# Altium schematic sheet MM_Radar.SchDoc(MM_Radar)
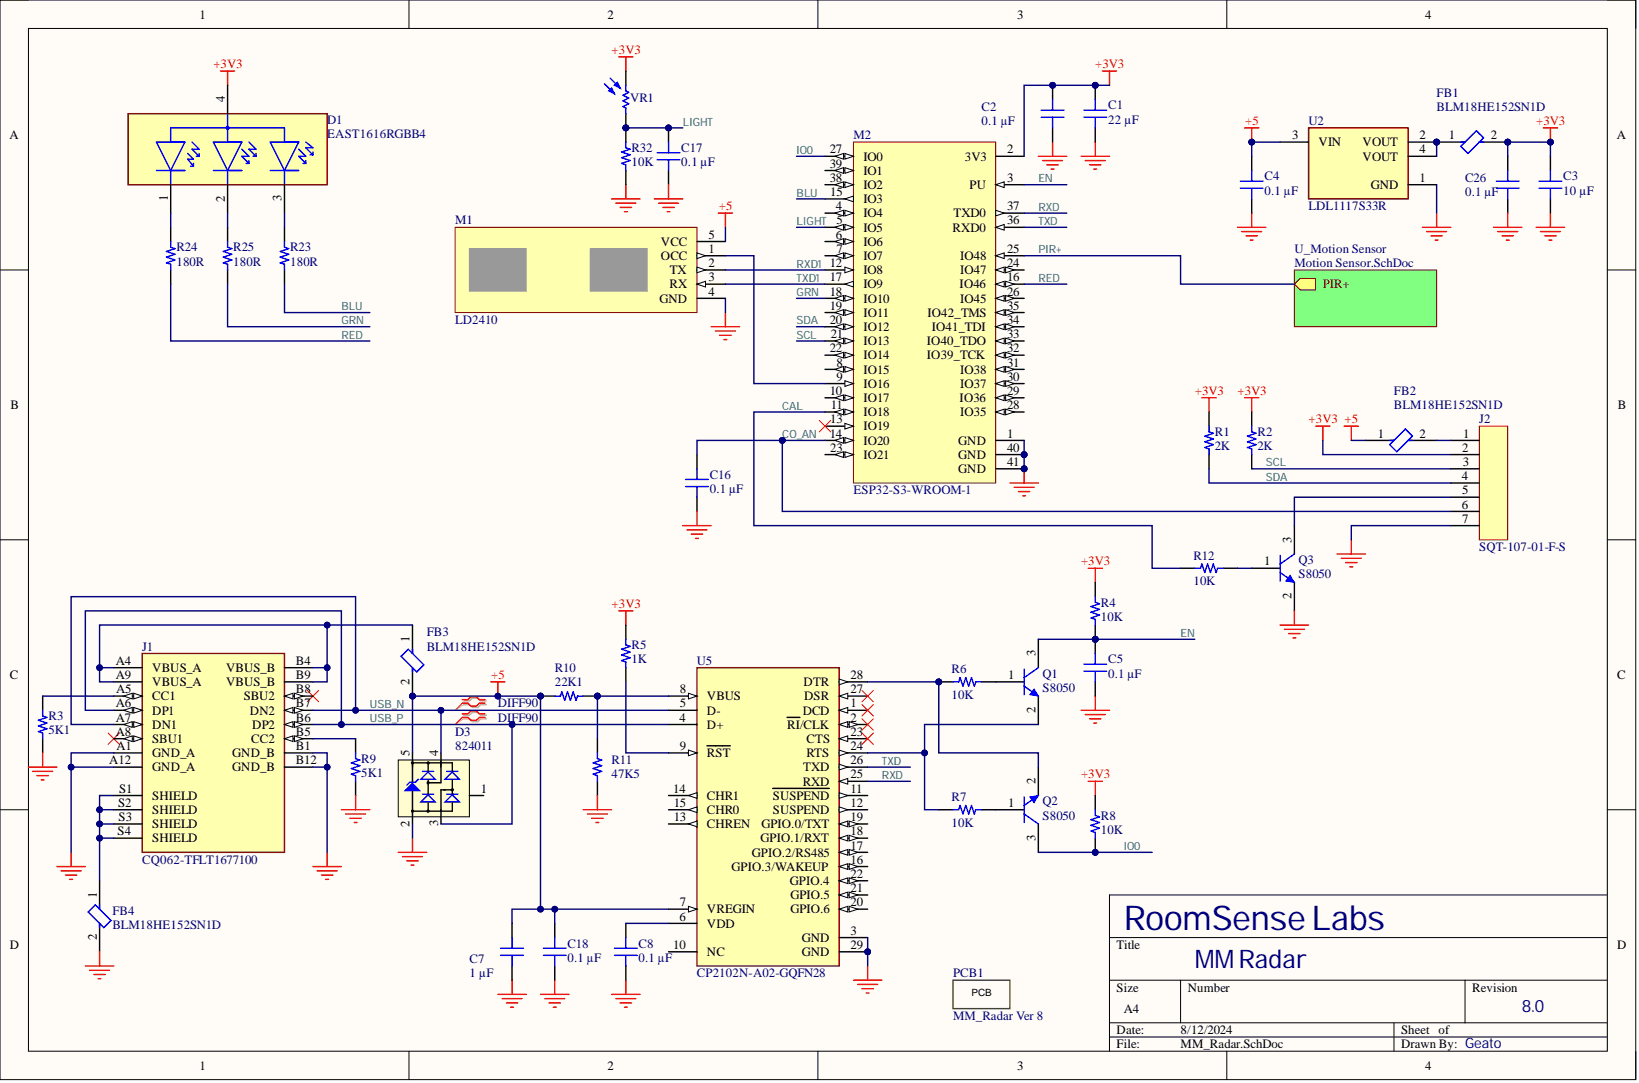
[diagram p0: MM_Radar.SchDoc]

## Parts
C1  22 µF
C2  0.1 µF
C3  10 µF
C4  0.1 µF
C5  0.1 µF
C7  1 µF
C8  0.1 µF
C16  0.1 µF
C17  0.1 µF
C18  0.1 µF
C26  0.1 µF
D1  EAST1616RGBB4
D3  824011
FB1  BLM18HE152SN1D
FB2  BLM18HE152SN1D
FB3  BLM18HE152SN1D
FB4  BLM18HE152SN1D
J1  CQ062-TFLT1677100
J2  SQT-107-01-F-S
M1  LD2410
M2  ESP32-S3-WROOM-1
PCB1  MM_Radar Ver 8  (mechanical)
Q1  S8050
Q2  S8050
Q3  S8050
R1  2K
R2  2K
R3  5K1
R4  10K
R5  1K
R6  10K
R7  10K
R8  10K
R9  5K1
R10  22K1
R11  47K5
R12  10K
R23  180R
R24  180R
R25  180R
R32  10K
U2  LDL1117S33R
U5  CP2102N-A02-GQFN28
VR1  AF161  (value not drawn)

## Nets
+3V3: C1.2 C2.2 C3.2 C26.2 D1.4 FB1.2 J2.2 M2.2(3V3) R1.2 R2.2 R4.2 R5.2 R8.1 VR1.2
+5: C4.2 C7.2 C18.2 D3.5 FB2.1 FB3.2 M1.5(VCC) R10.1 U2.3(VIN) U5.7(VREGIN)
GND: C1.1 C2.1 C3.1 C4.1 C5.1 C7.1 C8.1 C16.1 C17.1 C18.1 C26.1 D3.2 FB4.2 J1.A1(GND_A) J1.A12(GND_A) J1.B1(GND_B) J1.B12(GND_B) J2.7 M1.4(GND) M2.1(GND) M2.40(GND) M2.41(GND) Q3.2 R3.1 R9.1 R11.1 R32.1 U2.1(GND) U5.3(GND) U5.29(GND)
BLU: M2.15(IO3) R23.1
CAL: M2.11(IO18) R12.1
CO_AN: C16.2 J2.6 M2.14(IO20)
EN: C5.2 M2.3(PU) Q1.3 R4.1
GRN: M2.18(IO10) R25.1
IO0: M2.27(IO0) Q2.3 R8.2
LIGHT: C17.2 M2.5(IO5) R32.2 VR1.1
PIR+: M2.25(IO48)
RED: M2.16(IO46) R24.1
RXD: M2.37(TXD0) U5.25(RXD)
RXD1: M1.2(TX) M2.12(IO8)
SCL: J2.3 M2.21(IO13) R2.1
SDA: J2.4 M2.20(IO12) R1.1
TXD: M2.36(RXD0) U5.26(TXD)
TXD1: M1.3(RX) M2.17(IO9)
USB_N: D3.4 J1.A7(DN1) J1.B7(DN2) U5.5(D-)
USB_P: D3.3 J1.A6(DP1) J1.B6(DP2) U5.4(D+)
NetC8_2: C8.2 U5.6(VDD)
NetD1_1: D1.1 R24.2
NetD1_2: D1.2 R25.2
NetD1_3: D1.3 R23.2
NetD3_1: D3.1
NetFB1_1: FB1.1 U2.2(VOUT) U2.4(VOUT)
NetFB2_2: FB2.2 J2.1
NetFB3_1: FB3.1 J1.A4(VBUS_A) J1.A9(VBUS_A) J1.B4(VBUS_B) J1.B9(VBUS_B)
NetFB4_1: FB4.1 J1.S1(SHIELD) J1.S2(SHIELD) J1.S3(SHIELD) J1.S4(SHIELD)
NetJ1_A5: J1.A5(CC1) R3.2
NetJ1_A8: J1.A8(SBU1)
NetJ1_B5: J1.B5(CC2) R9.2
NetJ1_B8: J1.B8(SBU2)
NetJ2_5: J2.5 Q3.3
NetM1_1: M1.1(OCC) M2.9(IO16)
NetM2_4: M2.4(IO4)
NetM2_6: M2.6(IO6)
NetM2_7: M2.7(IO7)
NetM2_8: M2.8(IO15)
NetM2_10: M2.10(IO17)
NetM2_13: M2.13(IO19)
NetM2_19: M2.19(IO11)
NetM2_22: M2.22(IO14)
NetM2_23: M2.23(IO21)
NetM2_24: M2.24(IO47)
NetM2_26: M2.26(IO45)
NetM2_28: M2.28(IO35)
NetM2_29: M2.29(IO36)
NetM2_30: M2.30(IO37)
NetM2_31: M2.31(IO38)
NetM2_32: M2.32(IO39_TCK)
NetM2_33: M2.33(IO40_TDO)
NetM2_34: M2.34(IO41_TDI)
NetM2_35: M2.35(IO42_TMS)
NetM2_38: M2.38(IO2)
NetM2_39: M2.39(IO1)
NetQ1_1: Q1.1 R6.2
NetQ1_2: Q1.2 R7.1 U5.24(RTS)
NetQ2_1: Q2.1 R7.2
NetQ2_2: Q2.2 R6.1 U5.28(DTR)
NetQ3_1: Q3.1 R12.2
NetR5_1: R5.1 U5.9(RST)
NetR10_2: R10.2 R11.2 U5.8(VBUS)
NetU5_1: U5.1(DCD)
NetU5_2: U5.2(RI/CLK)
NetU5_10: U5.10(NC)
NetU5_11: U5.11(SUSPEND)
NetU5_12: U5.12(SUSPEND)
NetU5_13: U5.13(CHREN)
NetU5_14: U5.14(CHR1)
NetU5_15: U5.15(CHR0)
NetU5_16: U5.16(GPIO.3/WAKEUP)
NetU5_17: U5.17(GPIO.2/RS485)
NetU5_18: U5.18(GPIO.1/RXT)
NetU5_19: U5.19(GPIO.0/TXT)
NetU5_20: U5.20(GPIO.6)
NetU5_21: U5.21(GPIO.5)
NetU5_22: U5.22(GPIO.4)
NetU5_23: U5.23(CTS)
NetU5_27: U5.27(DSR)

## Library data of the parts
C1 = CC0805MKX5R6BB226: CAP CER 22UF 10V X5R 0805; manufacturer: Yageo; Database Table Name: Capacitors - Ceramic - 0805; Tolerance: ±20%; Applications: General Purpose; Capacitance: 22 µF; Component Kind: Standard; componentlink1description: Datasheet; componentlink1url: https://www.yageo.com/upload/media/product/productsearch/datasheet/mlcc/UPY-GPHC_X5R_4V-to-50V_25.pdf; componentlink2description: DigiKey Link; componentlink2url: https://www.digikey.com/en/products/detail/yageo/CC0805MKX5R6BB226/5195306; Device Package: 0805 (2012 Metric); lastupdated: 10/07/2023 7:11:49 PM; Library Name: Celestial Altium Library - altium_library.DbLib; Lifecycle Status: Active; Minimum Order: 1; Mounting Type: Surface Mount, MLCC; Operating Temperature: -55°C ~ 85°C; Package / Case: 0805 (2012 Metric); packaging: TapeAndReel; Part Number: CC0805MKX5R6BB226; Part Status: Active; partid: 8890; price: 0.07; series: CC; Size / Dimension: 0.079" L x 0.049" W (2.00mm x 1.25mm); Supplier 1: DigiKey; Supplier Part Number 1: 311-1900-1-ND; Temperature Coefficient: X5R; Thickness (Max): 0.057" (1.45mm); Value: 22 µF; Voltage - Rated: 10V
C2 C4 C5 C8 C16-C18 C26 = CL10B104KB8NNNL: CAP CER 0.1UF 50V X7R 0603; manufacturer: Samsung Electro-Mechanics; Database Table Name: Capacitors - Ceramic - 0603; Tolerance: ±10%; Applications: General Purpose; Capacitance: 0.1 µF; Component Kind: Standard; componentlink1description: Datasheet; componentlink1url: http://www.samsungsem.com/kr/support/product-search/mlcc/CL10B104KB8NNNL.jsp; componentlink2description: DigiKey Link; componentlink2url: https://www.digikey.com/en/products/detail/samsung-electro-mechanics/CL10B104KB8NNNL/3894274; Device Package: 0603 (1608 Metric); lastupdated: 10/07/2023 7:07:55 PM; Library Name: Celestial Altium Library - altium_library.DbLib; Lifecycle Status: Active; Minimum Order: 1; Mounting Type: Surface Mount, MLCC; Operating Temperature: -55°C ~ 125°C; Package / Case: 0603 (1608 Metric); packaging: CutTape; Part Number: CL10B104KB8NNNL; Part Status: Active; partid: 3517; price: 0.01; series: CL; Size / Dimension: 0.063" L x 0.031" W (1.60mm x 0.80mm); Supplier 1: DigiKey; Supplier Part Number 1: 1276-CL10B104KB8NNNLCT-ND; Temperature Coefficient: X7R; Thickness (Max): 0.035" (0.90mm); Value: 0.1 µF; Voltage - Rated: 50V
C3 = CC0805KKX5R6BB106: CAP CER 10UF 10V X5R 0805; manufacturer: Yageo; Database Table Name: Capacitors - Ceramic - 0805; Tolerance: ±10%; Applications: General Purpose; Capacitance: 10 µF; Component Kind: Standard; componentlink1description: Datasheet; componentlink1url: https://www.yageo.com/upload/media/product/productsearch/datasheet/mlcc/UPY-GPHC_X5R_4V-to-50V_25.pdf; componentlink2description: DigiKey Link; componentlink2url: https://www.digikey.com/en/products/detail/yageo/CC0805KKX5R6BB106/2833625; Device Package: 0805 (2012 Metric); lastupdated: 10/07/2023 7:10:42 PM; Library Name: Celestial Altium Library - altium_library.DbLib; Lifecycle Status: Active; Minimum Order: 1; Mounting Type: Surface Mount, MLCC; Operating Temperature: -55°C ~ 85°C; Package / Case: 0805 (2012 Metric); packaging: TapeAndReel; Part Number: CC0805KKX5R6BB106; Part Status: Active; partid: 44305; price: 0.08; series: CC; Size / Dimension: 0.079" L x 0.049" W (2.00mm x 1.25mm); Supplier 1: DigiKey; Supplier Part Number 1: 311-1460-1-ND; Temperature Coefficient: X5R; Value: 10 µF; Voltage - Rated: 10V
C7 = CC0603KRX7R9BB105: CAP CER 1UF 50V X7R 0603; manufacturer: Yageo; Database Table Name: Capacitors - Ceramic - 0603; Tolerance: ±10%; Applications: General Purpose; Capacitance: 1 µF; Component Kind: Standard; componentlink1description: Datasheet; componentlink1url: https://www.yageo.com/upload/media/product/productsearch/datasheet/mlcc/UPY-GPHC_X7R_6.3V-to-50V_20.pdf; componentlink2description: DigiKey Link; componentlink2url: https://www.digikey.com/en/products/detail/yageo/CC0603KRX7R9BB105/5883796; Device Package: 0603 (1608 Metric); lastupdated: 06/07/2023 7:07:23 PM; Library Name: Celestial Altium Library - altium_library.DbLib; Lifecycle Status: Active; Minimum Order: 1; Mounting Type: Surface Mount, MLCC; Operating Temperature: -55°C ~ 125°C; Package / Case: 0603 (1608 Metric); packaging: TapeAndReel; Part Number: CC0603KRX7R9BB105; Part Status: Active; partid: 45844; price: 0.09; series: CC; Size / Dimension: 0.063" L x 0.031" W (1.60mm x 0.80mm); Supplier 1: DigiKey; Supplier Part Number 1: 311-2082-1-ND; Temperature Coefficient: X7R; Thickness (Max): 0.035" (0.90mm); Value: 1 µF; Voltage - Rated: 50V
D1 = CMP-51564-000009-1: LED RGB CLEAR 4SMD; Color: Blue; Element Configuration: Common Anode; Forward Voltage: 2.8V; Lens Color: Colorless; Lens Size: 1.1mm; Lens Style: Flat; Test Current: 5mA; Viewing Angle: 120°; Case Code (Imperial): 0606; Case Code (Metric): 1616; Case/Package: 0606; Component Kind: Standard; Height: 0.45mm; Length: 1.6mm; Lens Transparency: Clear; Library Name: Altium Content Vault; Mount: Surface Mount; Packaging: Tape and Reel; Width: 1.6mm
D3 = CMP-1474-00002-1: TVS Diode WE-TVS-HS, VRWM=5V; Manufacturer: Wuerth Elektronik; Reverse Stand Off Voltage: 5V; Component Kind: Standard; ComponentLink1Description: Manufacturer URL; ComponentLink1URL: http://www.we-online.com; ComponentLink2Description: Datasheet; ComponentLink2URL: http://katalog.we-online.de/kataloge/eisos/media/pdf/824011.pdf; Library Name: Altium Content Vault; PackageReference: SOT23_5L; PartNumber: 824011
FB1-FB4 = CMP-0686-00368-3: Chip Ferrite Bead, 0603, 1500Ω @ 100MHz, 0.5Ω, 25%, 500mA; DC Resistance (DCR): 500mR; Impedance: 1.5kR; Number of Circuits: 1; Case Code (Imperial): 0603; Case Code (Metric): 1608; Case/Package: 0603; Component Kind: Standard; Core Material: Ferrite; Current Rating: 500mA; DC Current: 500mA; Depth: 0.8mm; Height: 0.8mm; Lead Free: Lead Free; Length: 1.6mm; Library Name: Altium Content Vault; Material: Ferrite; Max Current Rating: 500mA; Max DC Current: 500mA; Max Operating Temperature: 125°C; Military Standard: Not; Min Operating Temperature: -55°C; Number of Lines: 1; Number of Pins: 2; Package Quantity: 1; Packaging: Tape and Reel; Radiation Hardening: No; REACH SVHC: No SVHC; Termination: SMD/SMT; Test Frequency: 100MHz; Tolerance: 25%; Width: 0.8mm
J1 = CMP-00038-1: USC C Connector PCB mount Verticle; Component Kind: Standard; Library Name: Greg Eaton
J2 = CMP-002-00096-2: Headers & Wire Housings Cost Effective Rugged PCB Sockets, 2.00mm pitch; Connector Type: Receptacle; Gender: Female; Number of Contacts: 7; Pitch: 2mm; Voltage Rating (AC): 450V; Component Kind: Standard; Contact Finish - Mating: GOLD; Contact Material: Bronze; Contact Plating: Gold; Contact Shape: Square; Contact Type: Forked; Fastening Type: Pull; Flammability Rating: UL94 V-0; Insulation Color: Black; Insulation Material: Polymer; Lead Free: Lead Free; Max Operating Temperature: 125°C; Min Operating Temperature: -55°C; Number of Positions: 7; Number of Rows: 1; Packaging: Rail/Tube; Radiation Hardening: No; RoHS Compliant: Yes; Series: SQT; Termination: Solder; Library Name: Greg Eaton
M1 = CMP-00025-00007-1: 24GHz Radar Module; Component Kind: Standard; Library Name: Greg Eaton
M2 = CMP-020-00023-1: SMD MODULE, ESP32; Component Kind: Standard; Library Name: Greg Eaton
PCB1 = PCB_Blank: Library Name: Pathfinder.SchLib
Q1-Q3 = CMP-0564-00001-2: NPN Transistor, 0.8 A Collector Current, 3-Pin SOT23, RoHS, Tape and Reel; Manufacturer: Koo Chin; fT(min): 150 MHz; ICBO (max): 0.1 uA; V (BR) CBO (min): 40 V; V (BR) CEO (min): 25 V; V (BR) EBO (min): 5 V; VBE (sat) (max): 1.2 V; VCE (sat) (max): 0.6 V; Component Kind: Standard; ComponentLink1Description: Manufacturer URL; ComponentLink1URL: http://www.hz-dz.net/; ComponentLink2Description: Datasheet; ComponentLink2URL: http://www.hz-dz.net/UploadFiles/2009812164318190.pdf; ComponentLink3Description: Package Specification; ComponentLink3URL: http://galaxycn.com/jsp/upload/S8050.pdf; DatasheetVersion: 17/08/2009; ICEO (max): 0.1 uA; IEBO (max): 0.1 uA; Library Name: Altium Content Vault; Mounting Technology: Surface Mount; NPN/PNP: NPN; PackageDescription: 3-Pin Small Out-Line Transistor, Body 1.3 x 2.9 mm, Pitch 0.95 mm; PackageReference: SOT23-3; Packing: Tape and Reel; PartNumber: S8050; RoHS: TRUE
R1 R2 = RC0603FR-072KL: RES 2K OHM 1% 1/10W 0603; Manufacturer: Yageo; Database Table Name: Resistors - Surface Mount - 0603; Power (Watts): 0.1W, 1/10W; Resistance: 2 kOhms; Temperature Coefficient: ±100ppm/°C; Component Kind: Standard; ComponentLink1Description: Datasheet; ComponentLink1URL: https://www.yageo.com/upload/media/product/productsearch/datasheet/rchip/PYu-RC_Group_51_RoHS_L_10.pdf; ComponentLink2Description: DigiKey Link; ComponentLink2URL: https://www.digikey.com/en/products/detail/yageo/RC0603FR-072KL/727009; Composition: Thick Film; Device Package: 0603 (1608 Metric); Features: Moisture Resistant; Height - Seated (Max): 0.022" (0.55mm); LastUpdated: 10/07/2023 7:32:53 PM; Library Name: Celestial Altium Library - altium_library.DbLib; Lifecycle Status: Active; Minimum Order: 1; Number of Terminations: 2; Operating Temperature: -55°C ~ 155°C; Package / Case: 0603 (1608 Metric); Packaging: CutTape; Part Number: RC0603FR-072KL; Part Status: Active; PartId: 23952; Price: 0.01; Series: RC_L; Size / Dimension: 0.063" L x 0.031" W (1.60mm x 0.80mm); Supplier 1: DigiKey; Supplier Device Package: 0603; Supplier Part Number 1: 311-2.00KHRCT-ND; Tolerance: ±1%; Value: 2K
R3 R9 = RC0603FR-135K1L: RES 5.1K OHM 1% 1/10W 0603; Manufacturer: Yageo; Database Table Name: Resistors - Surface Mount - 0603; Power (Watts): 0.1W, 1/10W; Resistance: 5.1 kOhms; Temperature Coefficient: ±100ppm/°C; Component Kind: Standard; ComponentLink1Description: Datasheet; ComponentLink1URL: https://www.yageo.com/upload/media/product/productsearch/datasheet/rchip/PYu-RC_Group_51_RoHS_L_11.pdf; ComponentLink2Description: DigiKey Link; ComponentLink2URL: https://www.digikey.com/en/products/detail/yageo/RC0603FR-135K1L/14008317; Composition: Thick Film; Device Package: 0603 (1608 Metric); Height - Seated (Max): 0.022" (0.55mm); LastUpdated: 10/07/2023 7:32:07 PM; Library Name: Celestial Altium Library - altium_library.DbLib; Lifecycle Status: Active; Minimum Order: 1; Number of Terminations: 2; Operating Temperature: -55°C ~ 155°C; Package / Case: 0603 (1608 Metric); Packaging: CutTape; Part Number: RC0603FR-135K1L; Part Status: Active; PartId: 65613; Price: 0.01; Series: RC_L; Size / Dimension: 0.063" L x 0.031" W (1.60mm x 0.80mm); Supplier 1: DigiKey; Supplier Device Package: 0603; Supplier Part Number 1: 13-RC0603FR-135K1LCT-ND; Tolerance: ±1%; Value: 5K1
R4 R6-R8 R12 R32 = RC0603JR-0710KL: RES 10K OHM 5% 1/10W 0603; Manufacturer: Yageo; Database Table Name: Resistors - Surface Mount - 0603; Power (Watts): 0.1W, 1/10W; Resistance: 10 kOhms; Temperature Coefficient: ±100ppm/°C; Component Kind: Standard; ComponentLink1Description: Datasheet; ComponentLink1URL: https://www.yageo.com/upload/media/product/productsearch/datasheet/rchip/PYu-RC_Group_51_RoHS_L_10.pdf; ComponentLink2Description: DigiKey Link; ComponentLink2URL: https://www.digikey.com/en/products/detail/yageo/RC0603JR-0710KL/726700; Composition: Thick Film; Device Package: 0603 (1608 Metric); Features: Moisture Resistant; Height - Seated (Max): 0.022" (0.55mm); LastUpdated: 10/07/2023 7:33:02 PM; Library Name: Celestial Altium Library - altium_library.DbLib; Lifecycle Status: Active; Minimum Order: 1; Number of Terminations: 2; Operating Temperature: -55°C ~ 155°C; Package / Case: 0603 (1608 Metric); Packaging: CutTape; Part Number: RC0603JR-0710KL; Part Status: Active; PartId: 22593; Price: 0.01; Series: RC_L; Size / Dimension: 0.063" L x 0.031" W (1.60mm x 0.80mm); Supplier 1: DigiKey; Supplier Device Package: 0603; Supplier Part Number 1: 311-10KGRCT-ND; Tolerance: ±5%; Value: 10K
R5 = RC0603FR-101KL: RES 1K OHM 1% 1/10W 0603; Manufacturer: Yageo; Database Table Name: Resistors - Surface Mount - 0603; Power (Watts): 0.1W, 1/10W; Resistance: 1 kOhms; Temperature Coefficient: ±100ppm/°C; Component Kind: Standard; ComponentLink1Description: Datasheet; ComponentLink1URL: https://www.yageo.com/upload/media/product/productsearch/datasheet/rchip/PYu-RC_Group_51_RoHS_L_11.pdf; ComponentLink2Description: DigiKey Link; ComponentLink2URL: https://www.digikey.com/en/products/detail/yageo/RC0603FR-101KL/12756391; Composition: Thick Film; Device Package: 0603 (1608 Metric); Features: Moisture Resistant; Height - Seated (Max): 0.022" (0.55mm); LastUpdated: 09/02/2024 8:41:10 PM; Library Name: Celestial Altium Library - altium_library.DbLib; Lifecycle Status: Active; Minimum Order: 1; Number of Terminations: 2; Operating Temperature: -55°C ~ 155°C; Package / Case: 0603 (1608 Metric); Packaging: CutTape; Part Number: RC0603FR-101KL; Part Status: Active; PartId: 68024; Price: 0.01; Series: RC_L; Size / Dimension: 0.063" L x 0.031" W (1.60mm x 0.80mm); Supplier 1: DigiKey; Supplier Device Package: 0603; Supplier Part Number 1: 13-RC0603FR-101KLCT-ND; Tolerance: ±1%; Value: 1K
R10 = RC0603FR-0722K1L: RES 22.1K OHM 1% 1/10W 0603; Manufacturer: Yageo; Database Table Name: Resistors - Surface Mount - 0603; Power (Watts): 0.1W, 1/10W; Resistance: 22.1 kOhms; Temperature Coefficient: ±100ppm/°C; Component Kind: Standard; ComponentLink1Description: Datasheet; ComponentLink1URL: https://www.yageo.com/upload/media/product/productsearch/datasheet/rchip/PYu-RC_Group_51_RoHS_L_10.pdf; ComponentLink2Description: DigiKey Link; ComponentLink2URL: https://www.digikey.com/en/products/detail/yageo/RC0603FR-0722K1L/727058; Composition: Thick Film; Device Package: 0603 (1608 Metric); Features: Moisture Resistant; Height - Seated (Max): 0.022" (0.55mm); LastUpdated: 09/02/2024 8:42:41 PM; Library Name: Celestial Altium Library - altium_library.DbLib; Lifecycle Status: Active; Minimum Order: 1; Number of Terminations: 2; Operating Temperature: -55°C ~ 155°C; Package / Case: 0603 (1608 Metric); Packaging: CutTape; Part Number: RC0603FR-0722K1L; Part Status: Active; PartId: 19017; Price: 0.01; Series: RC_L; Size / Dimension: 0.063" L x 0.031" W (1.60mm x 0.80mm); Supplier 1: DigiKey; Supplier Device Package: 0603; Supplier Part Number 1: 311-22.1KHRCT-ND; Tolerance: ±1%; Value: 22K1
R11 = RC0603FR-0747K5L: RES 47.5K OHM 1% 1/10W 0603; Manufacturer: Yageo; Database Table Name: Resistors - Surface Mount - 0603; Power (Watts): 0.1W, 1/10W; Resistance: 47.5 kOhms; Temperature Coefficient: ±100ppm/°C; Component Kind: Standard; ComponentLink1Description: Datasheet; ComponentLink1URL: https://www.yageo.com/upload/media/product/productsearch/datasheet/rchip/PYu-RC_Group_51_RoHS_L_10.pdf; ComponentLink2Description: DigiKey Link; ComponentLink2URL: https://www.digikey.com/en/products/detail/yageo/RC0603FR-0747K5L/727255; Composition: Thick Film; Device Package: 0603 (1608 Metric); Features: Moisture Resistant; Height - Seated (Max): 0.022" (0.55mm); LastUpdated: 09/02/2024 8:42:42 PM; Library Name: Celestial Altium Library - altium_library.DbLib; Lifecycle Status: Active; Minimum Order: 1; Number of Terminations: 2; Operating Temperature: -55°C ~ 155°C; Package / Case: 0603 (1608 Metric); Packaging: CutTape; Part Number: RC0603FR-0747K5L; Part Status: Active; PartId: 18975; Price: 0.01; Series: RC_L; Size / Dimension: 0.063" L x 0.031" W (1.60mm x 0.80mm); Supplier 1: DigiKey; Supplier Device Package: 0603; Supplier Part Number 1: 311-47.5KHRCT-ND; Tolerance: ±1%; Value: 47K5
R23-R25 = RC0603FR-07180RL: RES 180 OHM 1% 1/10W 0603; Manufacturer: Yageo; Database Table Name: Resistors - Surface Mount - 0603; Power (Watts): 0.1W, 1/10W; Resistance: 180 Ohms; Temperature Coefficient: ±100ppm/°C; Component Kind: Standard; ComponentLink1Description: Datasheet; ComponentLink1URL: https://www.yageo.com/upload/media/product/productsearch/datasheet/rchip/PYu-RC_Group_51_RoHS_L_10.pdf; ComponentLink2Description: DigiKey Link; ComponentLink2URL: https://www.digikey.com/en/products/detail/yageo/RC0603FR-07180RL/726994; Composition: Thick Film; Device Package: 0603 (1608 Metric); Features: Moisture Resistant; Height - Seated (Max): 0.022" (0.55mm); LastUpdated: 10/07/2023 7:33:16 PM; Library Name: Celestial Altium Library - altium_library.DbLib; Lifecycle Status: Active; Minimum Order: 1; Number of Terminations: 2; Operating Temperature: -55°C ~ 155°C; Package / Case: 0603 (1608 Metric); Packaging: CutTape; Part Number: RC0603FR-07180RL; Part Status: Active; PartId: 19057; Price: 0.01; Series: RC_L; Size / Dimension: 0.063" L x 0.031" W (1.60mm x 0.80mm); Supplier 1: DigiKey; Supplier Device Package: 0603; Supplier Part Number 1: 311-180HRCT-ND; Tolerance: ±1%; Value: 180R
U2 = CMP-12153-000006-1: IC REG LINEAR 3.3V 1.2A SOT223; Manufacturer: STMicroelectronics; Accuracy Percentage: 2%; Dropout Voltage: 350mV; Max Junction Temp: +125°C; Max Supply Voltage: 18V; Min Supply Voltage: 2.6V; Nominal Supply Current: 250uA; Number Of Outputs: 1; Output Current: 2A; Output Type: Fixed; Standoff Height: 0.02mm; Automotive: No; Category: IC; Component Kind: Standard; ComponentLink2Description: Datasheet; ComponentLink2URL: https://www.st.com/resource/en/datasheet/ldl1117.pdf; Device Class L1: Integrated Circuits (ICs); Device Class L2: Power Management ICs; Device Class L3: Voltage Regulators - Linear; Digikey Description: IC REG LINEAR 3.3V 1.2A SOT223; Height: 1.9mm; Ipc Land Pattern Name: SOT230P700X190-4; Lead Free: Yes; Library Name: Altium Content Vault; Manufacturer Part Number: LDL1117S33R; Mouser Description: LDL1117 Series 1.2 A 3.3 V High PSRR LDO Linear Voltage Regulator-SOT-223; Octopart: https://octopart.com/ldl1117s33r-stmicroelectronics-81876163; Output Voltage: 3.3V; Package: SOT223-0046067; RoHS: TRUE; Temperature: -40°C to +125°C; Temperature Range High: +125°C; Temperature Range Low: -40°C
U5 = CMP-015-00008-1: SILICON LABS - CP2102N-A02-GQFN28 - Interface Bridges, USB to UART, 3 V, 3.6 V, QFN, 28 Pins, -40 °C; Component Kind: Standard; Library Name: Greg Eaton
VR1 = CMP-00025-1: CDS PHOTORESISTOR; Component Kind: Standard; Library Name: Greg Eaton
